# Altium schematic sheet Schematic.SchDoc(Schematic)
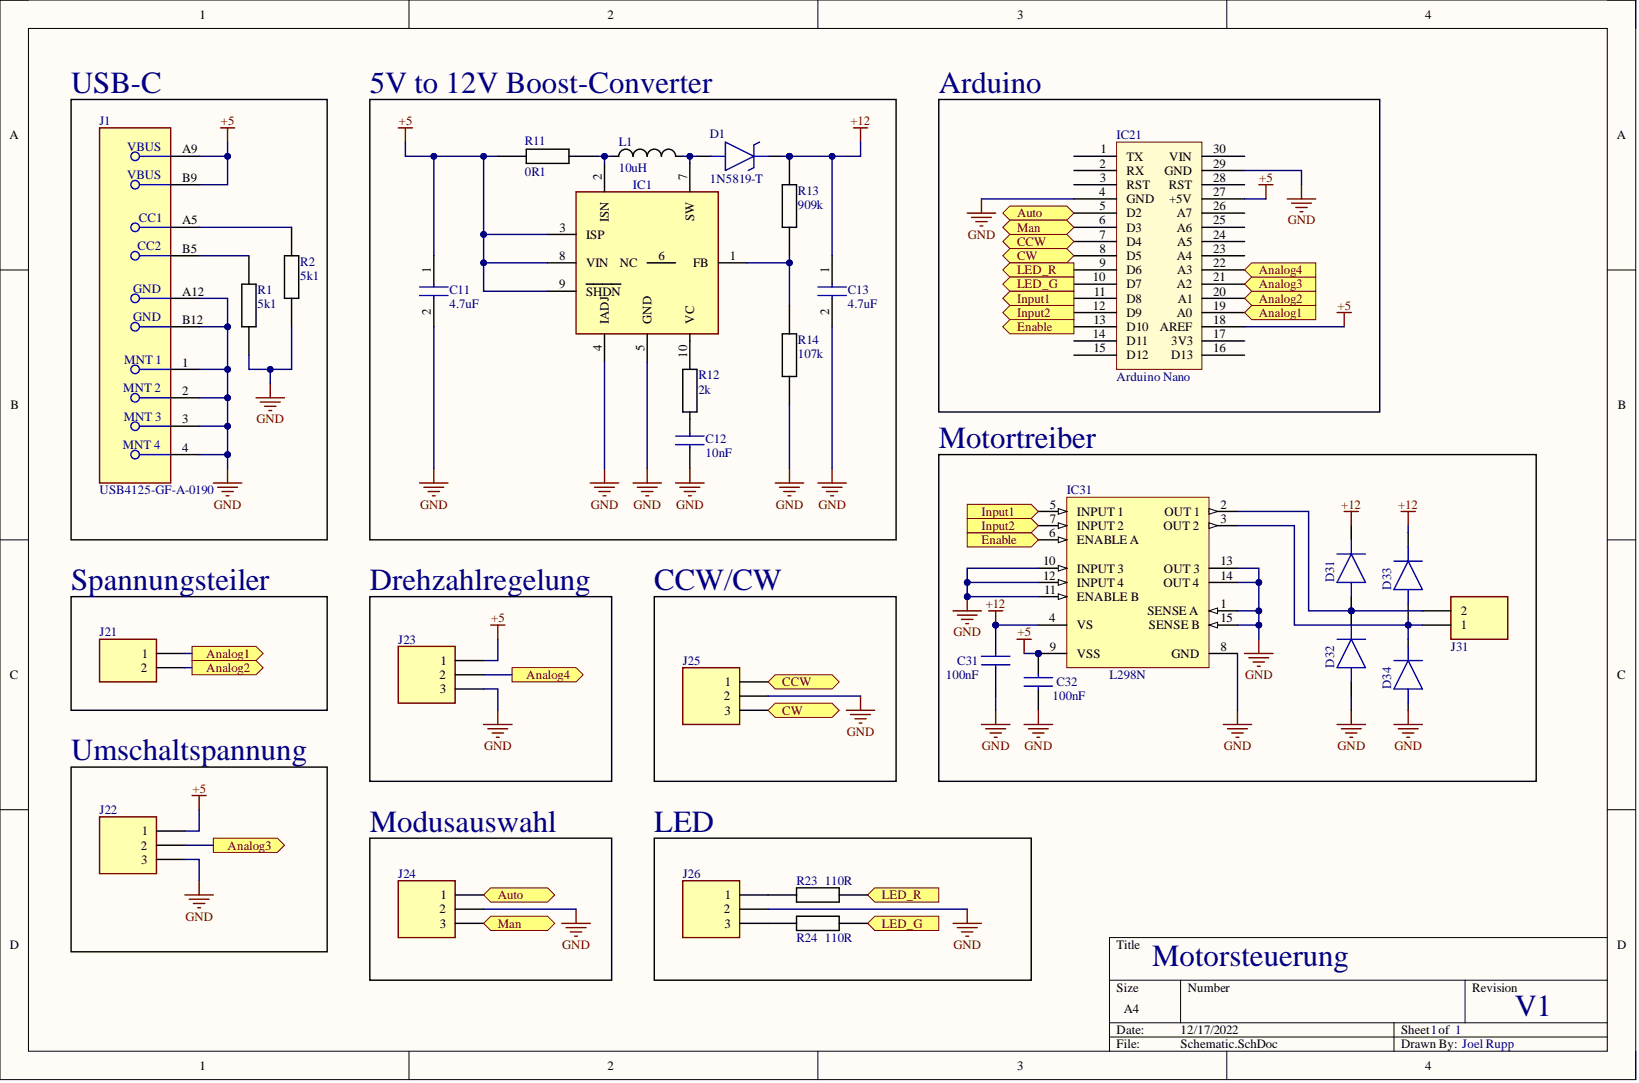
[diagram p0: Schematic.SchDoc]

## Parts
C11  4.7uF
C12  10nF
C13  4.7uF
C31  100nF
C32  100nF
D1  1N5819-T
D31  1N4933-E3_54  (value not drawn)
D32  1N4933-E3_54  (value not drawn)
D33  1N4933-E3_54  (value not drawn)
D34  1N4933-E3_54  (value not drawn)
IC1  LT1618EMS#PBF  (value not drawn)
IC21  Arduino Nano
IC31  L298N
J1  USB4125-GF-A-0190
J21  100V  (value not drawn)
J22  Drehzahl
J23  Drehzahl
J24  Modusauswahl
J25  Modusauswahl
J26  Modusauswahl
J31  100V  (value not drawn)
L1  10uH
R1  5k1
R2  5k1
R11  0R1
R12  2k
R13  909k
R14  107k
R23  110R
R24  110R

## Ports
Analog1, Analog2, Analog3, Analog4, Auto, CCW, CW, Enable, Input1, Input2, LED_G, LED_R, Man

## Nets
+5: C11.1 C32.2 IC1.3(ISP) IC1.8(VIN) IC1.9(SHDN) IC21.18(AREF) IC21.27(+5V) IC31.9(VSS) J1.A9 J1.B9 J22.1 J23.1 R11.1
+12: C13.1 C31.2 D1.1 D31.1 D33.1 IC31.4(VS) R13.2
GND: C11.2 C12.2 C13.2 C31.1 C32.1 D32.2 D34.2 IC1.4(IADJ) IC1.5(GND) IC21.4(GND) IC21.29(GND) IC31.1(SENSE_A) IC31.8(GND) IC31.10(INPUT_3) IC31.11(ENABLE_B) IC31.12(INPUT_4) IC31.13(OUT_3) IC31.14(OUT_4) IC31.15(SENSE_B) J1.1 J1.2 J1.3 J1.4 J1.A12 J1.B12 J22.3 J23.3 J24.2 J25.2 J26.2 R1.1 R2.1 R14.1
Analog1: IC21.19(A0) J21.1 ; port Analog1
Analog2: IC21.20(A1) J21.2 ; port Analog2
Analog3: IC21.21(A2) J22.2 ; port Analog3
Analog4: IC21.22(A3) J23.2 ; port Analog4
Auto: IC21.5(D2) J24.1 ; port Auto
CCW: IC21.7(D4) J25.1 ; port CCW
CW: IC21.8(D5) J25.3 ; port CW
Enable: IC21.13(D10) IC31.6(ENABLE_A) ; port Enable
Input1: IC21.11(D8) IC31.5(INPUT_1) ; port Input1
Input2: IC21.12(D9) IC31.7(INPUT_2) ; port Input2
LED_G: IC21.10(D7) R24.2 ; port LED_G
LED_R: IC21.9(D6) R23.2 ; port LED_R
Man: IC21.6(D3) J24.3 ; port Man
NetC12_1: C12.1 R12.2
NetD1_2: D1.2 IC1.7(SW) L1.2
NetD31_2: D31.2 D32.1 IC31.2(OUT_1) J31.2
NetD33_2: D33.2 D34.1 IC31.3(OUT_2) J31.1
NetIC1_1: IC1.1(FB) R13.1 R14.2
NetIC1_2: IC1.2(ISN) L1.1 R11.2
NetIC1_10: IC1.10(VC) R12.1
NetJ1_A5: J1.A5 R2.2
NetJ1_B5: J1.B5 R1.2
NetJ26_1: J26.1 R23.1
NetJ26_3: J26.3 R24.1

Not connected: IC1 6(NC); IC21 1(TX) 2(RX) 3(RST) 14(D11) 15(D12) 16(D13) 17(3V3) 23(A4) 24(A5) 25(A6) 26(A7) 28(RST) 30(VIN)

## Library data of the parts
C11 C13 = FG28X5R1E475KRT00: Capacitor; Manufacturer_Name: TDK; Component Kind: Standard; Datasheet Link: https://product.tdk.com/system/files/dam/doc/product/capacitor/ceramic/lead-mlcc/catalog/leadmlcc_halogenfree_fg_en.pdf; Height: 5.5mm; Manufacturer_Part_Number: FG28X5R1E475KRT00; Mouser Part Number: 810-FG28X5R1E475KRT0; Mouser Price/Stock: https://www.mouser.co.uk/ProductDetail/TDK/FG28X5R1E475KRT00?qs=vNwBHymccZ%252Bmi9bqqhZxiQ%3D%3D
C12 = K103K15X7RF5TL2: Capacitor; Manufacturer_Name: Vishay; Arrow Part Number: K103K15X7RF5TL2; Arrow Price/Stock: https://www.arrow.com/en/products/k103k15x7rf5tl2/vishay; Component Kind: Standard; Datasheet Link: https://www.vishay.com/docs/45171/kseries.pdf; Height: 5.6mm; Manufacturer_Part_Number: K103K15X7RF5TL2; Mouser Part Number: 594-K103K15X7RF5TL2; Mouser Price/Stock: https://www.mouser.co.uk/ProductDetail/Vishay-BC-Components/K103K15X7RF5TL2?qs=csx2tJjGIuPh3NBAhJ9UAg%3D%3D
C31 C32 = K104K15X7RF53H5: Capacitor; Manufacturer_Name: Vishay; Arrow Part Number: K104K15X7RF53H5; Arrow Price/Stock: https://www.arrow.com/en/products/k104k15x7rf53h5/vishay?region=nac; Component Kind: Standard; Datasheet Link: http://www.vishay.com/docs/45171/kseries.pdf; Manufacturer_Part_Number: K104K15X7RF53H5; Mouser Part Number: 594-K104K15X7RF53H5; Mouser Price/Stock: https://www.mouser.co.uk/ProductDetail/Vishay-BC-Components/K104K15X7RF53H5?qs=sYfpZ29HcUSlgH5bSUHkYw%3D%3D
D1 = 1N5819-T: Schottky-Diode; Manufacturer_Name: Rectron; Component Kind: Standard; Datasheet Link: https://mouser.componentsearchengine.com/Datasheets/1/1N5819-T.pdf; Manufacturer_Part_Number: 1N5819-T; Mouser Part Number: 583-1N5819-T; Mouser Price/Stock: https://www.mouser.co.uk/ProductDetail/Rectron/1N5819-T?qs=w4rXzN23dC3jxJaDspMQbA%3D%3D
D31-D34 = 1N4933-E3_54: Diode; Manufacturer_Name: Vishay; Manufacturer_Part_Number: 1N4933-E3/54; Arrow Part Number: 1N4933-E3/54; Arrow Price/Stock: https://www.arrow.com/en/products/1n4933-e354/vishay?region=nac; Component Kind: Standard; Datasheet Link: https://www.mouser.de/datasheet/2/427/1n4933-1768094.pdf; Mouser Part Number: 625-1N4933-E3; Mouser Price/Stock: https://www.mouser.co.uk/ProductDetail/Vishay-General-Semiconductor/1N4933-E3-54?qs=7w0fSgRKp92yavHdqQq%2FZQ%3D%3D
IC1 = LT1618EMS#PBF: Integrated Circuit; Manufacturer_Name: Analog Devices; Arrow Part Number: LT1618EMS#PBF; Arrow Price/Stock: https://www.arrow.com/en/products/lt1618emspbf/linear-technology; Component Kind: Standard; Datasheet Link: https://www.analog.com/media/en/technical-documentation/data-sheets/1618fas.pdf; Height: 1.1mm; Library Name: SamacSys.SchLib; Manufacturer_Part_Number: LT1618EMS#PBF; Mouser Part Number: 584-LT1618EMS#PBF; Mouser Price/Stock: https://www.mouser.co.uk/ProductDetail/Analog-Devices-Linear-Technology/LT1618EMSPBF?qs=ytflclh7QUXSkJbF7xtAZg%3D%3D
IC21 = Component_1: Component Kind: Standard; Library Name: Nano.SchLib
IC31 = CMP-2000-06526-1: Dual Full-Bridge Driver, 4.8 to 46 V, -40 to 150 degC, 15-Pin FM (MW 15L), RoHS, Tube; Manufacturer: STMicroelectronics; PartNumber: L298; Driver Case Style: Multiwatt; Motor Type: FullBridge; No. of Outputs: 4Outputs; No. of Pins: 15Pins; Output Current: 2A; Output Voltage: 46V; Supply Voltage Max: 7V; Supply Voltage Min: 4.5V; SVHC: NoSVHC(17-Dec-2015); Automotive Qualification Standard: -; Component Kind: Standard; Datasheet URL: https://datasheet.ciiva.com/15109/getdatasheetpartid-50682-15109332.pdf; Datasheet Version: 01/2000; Library Name: Altium Content Vault; Manufacturer URL: http://www.st.com/; Mounting Technology: ThroughHole; MSL: -; Operating Temperature Max: 130°C; Operating Temperature Min: -25°C; Package Description: 15-Pin Flange Mount, Body 5 x 19.6 mm, Pitch 1.27 mm; Package Reference: FM-15; Packaging: Each; Product Range: -
J1 = CMP-245163-000004-1: CONN RCPT TYPE C 6P SMD RA; Component Kind: Standard; Library Name: Altium Content Vault
J21 J31 = 1985920: Connector; Manufacturer_Name: Phoenix Contact; Component Kind: Standard; Datasheet Link: https://www.phoenixcontact.com/online/portal/us/pxc/product_detail_page/!ut/p/z1/3VfbjtowEP2VvOStiZ2LSVipDwQQ9zssJC9RLoZ1N7dNDCx8fR1g20IpqFqhqoksxXHmnDMzzlgZYIEFsCJnQ1YOJXHkBOzZtEr21JjW60O5JA1GyICtGqrWu-pYavZl8AwsYFHHBaaUzwLipk66A-Y6w1G-kBCfvSrrqCzDgymhAQ; Height: 15.15mm; Manufacturer_Part_Number: 1985920; Mouser Part Number: 651-1985920; Mouser Price/Stock: https://www.mouser.co.uk/ProductDetail/Phoenix-Contact/1985920?qs=bbdZqDPQhEUSSE389lnWMA%3D%3D
J22-J26 = 1985878: Connector; Manufacturer_Name: Phoenix Contact; Arrow Part Number: 1985878; Arrow Price/Stock: https://www.arrow.com/en/products/1985878/phoenix-contact; Component Kind: Standard; Datasheet Link: https://datasheet.datasheetarchive.com/originals/distributors/SFDatasheet-2/sf-00059104.pdf; Height: 10.15mm; Manufacturer_Part_Number: 1985878; Mouser Part Number: 651-1985878; Mouser Price/Stock: https://www.mouser.co.uk/ProductDetail/Phoenix-Contact/1985878?qs=2%252BM72CStpDS1DZf508BEJg%3D%3D
L1 = 10uH: Component Kind: Standard; Library Name: Library_THT.SchLib
R1 R2 = 5k1: Component Kind: Standard; Library Name: Library_THT.SchLib
R11 = 0R1: Component Kind: Standard; Library Name: Library_THT.SchLib
R12 = 2k: Component Kind: Standard; Library Name: Library_THT.SchLib
R13 = 909k: Component Kind: Standard; Library Name: Library_THT.SchLib
R14 = 107k: Component Kind: Standard; Library Name: Library_THT.SchLib
R23 R24 = 110R: Component Kind: Standard; Library Name: Library_THT.SchLib
